# Altium schematic sheet VHF_144.SchDoc
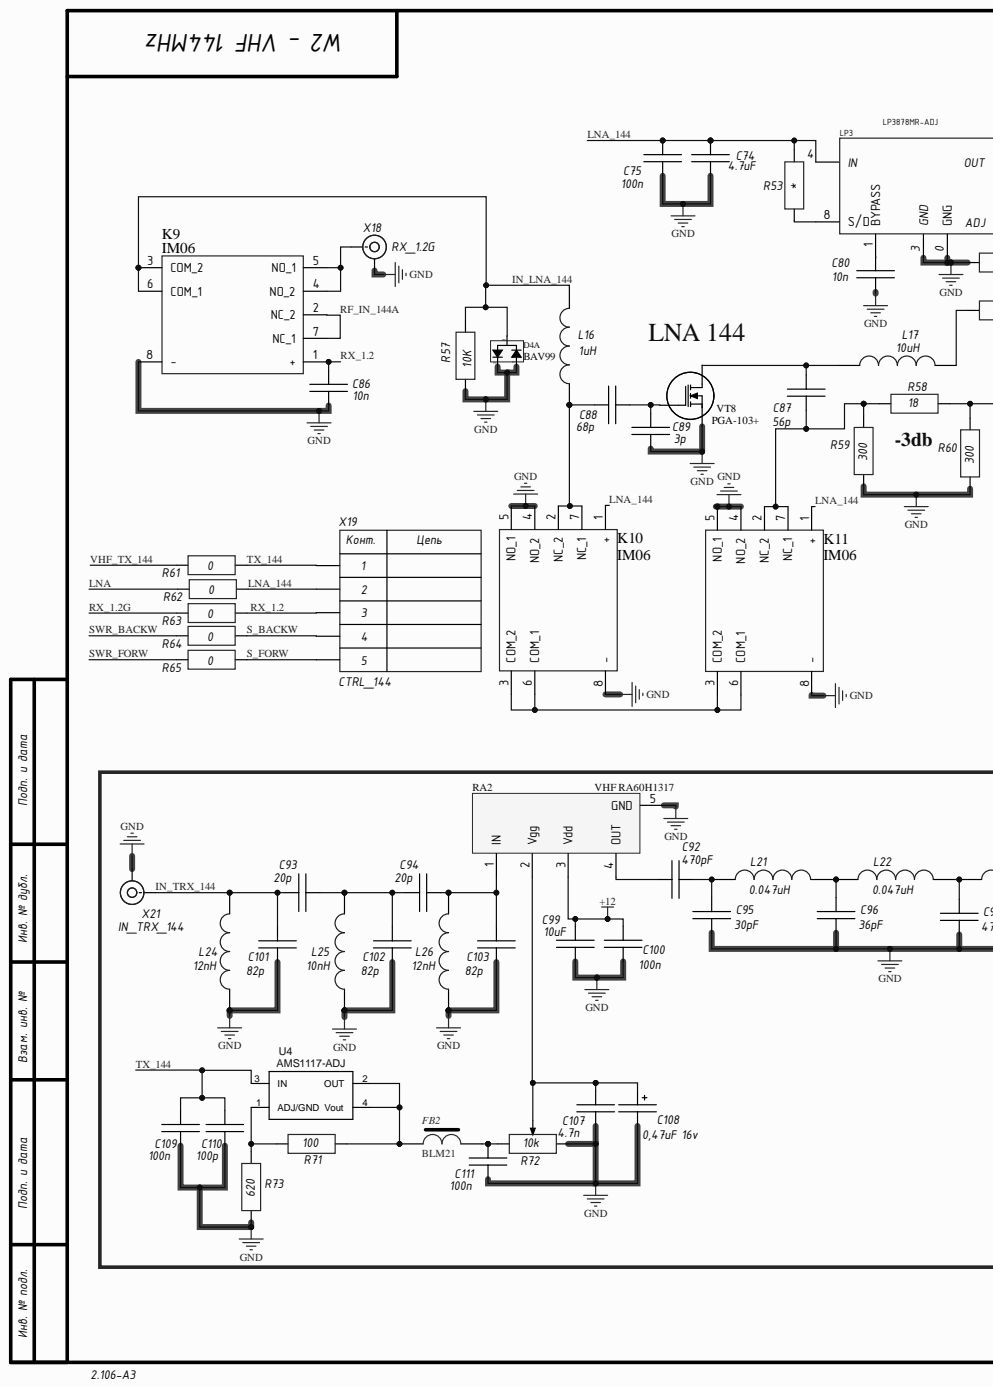
[diagram p0: VHF_144.SchDoc, left]
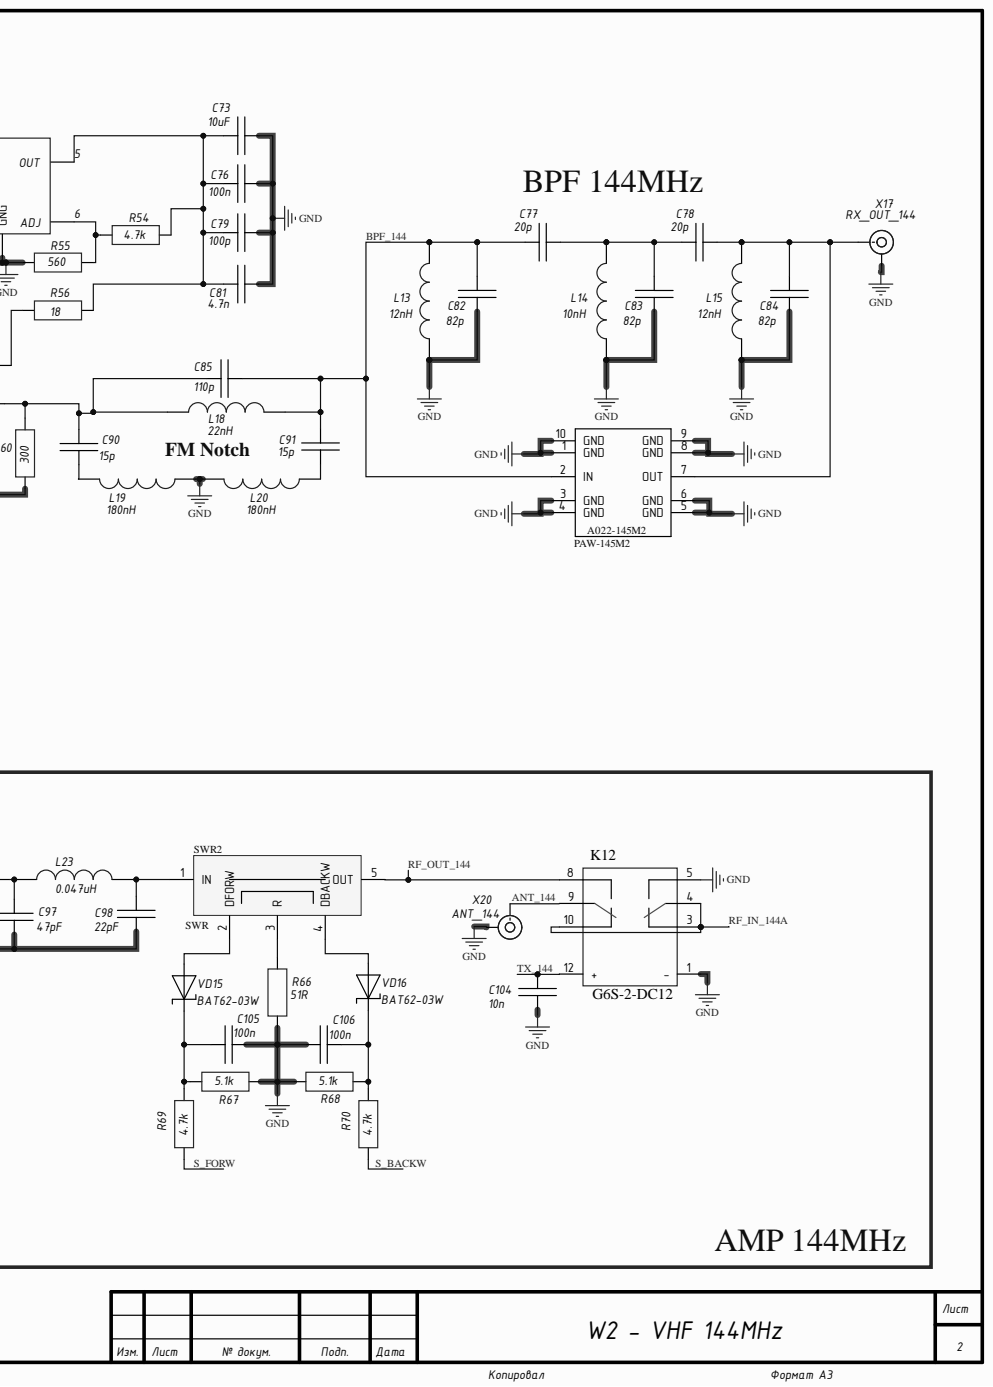
[diagram p1: VHF_144.SchDoc, right]

## Parts
C73  10uF  [CH0805C]
C74  4.7uF  [CH0805C]
C75  100n  [CH0805C]
C76  100n  [CH0805C]
C77  20p  [CH0805C]
C78  20p  [CH0805C]
C79  100p  [CH0805C]
C80  10n  [CH0805C]
C81  4.7n  [CH0805C]
C82  82p  [CH0805C]
C83  82p  [CH0805C]
C84  82p  [CH0805C]
C85  110p  [CH0805C]
C86  10n  [CH0805C]
C87  56p  [CH0805C]
C88  68p  [CH0805C]
C89  3p  [CH0805C]
C90  15p  [CH0805C]
C91  15p  [CH0805C]
C92  470pF  [CH1206ATC]
C93  20p  [CH0805C]
C94  20p  [CH0805C]
C95  30pF  [CH1206ATC]
C96  36pF  [CH1206ATC]
C97  47pF  [CH1206ATC]
C98  22pF  [CH1206ATC]
C99  10uF  [CH1206C]
C100  100n  [CH0805C]
C101  82p  [CH0805C]
C102  82p  [CH0805C]
C103  82p  [CH0805C]
C104  10n  [CH0805C]
C105  100n  [CH0805C]
C106  100n  [CH0805C]
C107  4.7n  [CH0805C]
C108  0,47uF 16v  [TANTAL_B]
C109  100n  [CH0805C]
C110  100p  [CH0805C]
C111  100n  [CH0805C]
D4  BAV99  [SOT23_132]  (part A)
FB2  BLM21  [CH0805L]
K9  IM06  [IM06]
K10  IM06  [IM06]
K11  IM06  [IM06]
K12  G6S-2-DC12  [G6S-2-DC12]
L13  12nH  [CH1206L]
L14  10nH  [CH1206L]
L15  12nH  [CH1206L]
L16  1uH  [CH1210L]
L17  10uH  [CH1210L]
L18  22nH  [CH1206L]
L19  180nH  [CH1206L]
L20  180nH  [CH1206L]
L21  0.047uH  [T50-6]
L22  0.047uH  [T50-6]
L23  0.047uH  [T50-6]
L24  12nH  [CH1206L]
L25  10nH  [CH1206L]
L26  12nH  [CH1206L]
LP3  LP3878MR-ADJ  [SOP-8 gnd]
PAW-1  PAW-145M2  [PAW-145M2]
R53  (no value)  [CH0805R]
R54  4.7k  [CH0805R]
R55  560  [CH0805R]
R56  18  [CH2010R]
R57  10K  [CH2010R]
R58  18  [CH0805R]
R59  300  [CH0805R]
R60  300  [CH0805R]
R61  0  [CH1206R]
R62  0  [CH1206R]
R63  0  [CH1206R]
R64  0  [CH1206R]
R65  0  [CH1206R]
R66  51R  [CH1206R]
R67  5.1k  [CH0805R]
R68  5.1k  [CH0805R]
R69  4.7k  [CH0805R]
R70  4.7k  [CH0805R]
R71  100  [CH0805R]
R72  10k  [RES: 3296W]
R73  620  [CH0805R]
RA2  VHF RA60H1317  [RA80H]
SWR2  SWR  [SWR_VHF]
U4  1117-ADJ  value AMS1117-ADJ  [LT1117CST-3.3]
VD15  BAT62-03W  [SOD323]
VD16  BAT62-03W  [SOD323]
VT8  PGA-103+  [SOT89_321_PGA103]
X17  RX_OUT_144  [CON: u.Fl]
X18  RX_1.2G  [CON: SMA]
X19  CTRL_144  [CON: PLS-5]
X20  ANT_144  [CON: SMA]
X21  IN_TRX_144  [CON: u.Fl]

## Nets
+12: C99.1 C100.2 RA2.3(Vdd)
GND: C73.2 C74.2 C75.2 C76.2 C79.2 C80.2 C81.2 C82.1 C83.1 C84.1 C86.1 C89.2 C95.1 C96.1 C97.1 C98.1 C99.2 C100.1 C101.1 C102.1 C103.1 C104.1 C105.1 C106.2 C107.1 C108.2 C109.2 C110.2 C111.1 D4.1 D4.2 K9.8(-) K10.4(NO_2) K10.5(NO_1) K10.8(-) K11.4(NO_2) K11.5(NO_1) K11.8(-) K12.1(-) K12.5 L13.2 L14.2 L15.2 L19.2 L20.1 L24.2 L25.2 L26.2 LP3.0(GNG) LP3.3(GND) PAW-1.1(GND) PAW-1.3(GND) PAW-1.4(GND) PAW-1.5(GND) PAW-1.6(GND) PAW-1.8(GND) PAW-1.9(GND) PAW-1.10(GND) R55.2 R57.1 R59.2 R60.2 R66.1 R67.1 R68.2 R72.3 R73.2 RA2.5(GND) VT8.2 X17.2 X18.2 X20.2 X21.2
ANT_144: K12.9 X20.1
BPF_144: C77.2 C82.2 C85.1 C91.2 L13.1 L18.1 PAW-1.2(IN)
IN_LNA_144: D4.3 K9.3(COM_2) K9.6(COM_1) L16.1 R57.2
IN_TRX_144: C93.2 C101.2 L24.1 X21.1
LNA: R62.1
LNA_144: C74.1 C75.1 K10.1(+) K11.1(+) LP3.4(IN) R53.1 R62.2 X19.2
RF_IN_144A: K9.2(NC_2) K9.7(NC_1) K12.3 K12.4 K12.10
RF_OUT_144: K12.8 SWR2.5(OUT)
RX_1.2: C86.2 K9.1(+) R63.2 X19.3
RX_1.2G: R63.1
S_BACKW: R64.2 R70.2 X19.4
S_FORW: R65.2 R69.2 X19.5
SWR_BACKW: R64.1
SWR_FORW: R65.1
TX_144: C104.2 C109.1 C110.1 K12.12(+) R61.2 U4.3(IN) X19.1
VHF_TX_144: R61.1
NetC73_1: C73.1 C76.1 C79.1 C81.1 LP3.5(OUT) R54.1 R56.1
NetC77_1: C77.1 C78.2 C83.2 L14.1
NetC78_1: C78.1 C84.2 L15.1 PAW-1.7(OUT) X17.1
NetC80_1: C80.1 LP3.1(BYPASS)
NetC85_2: C85.2 C90.2 L18.2 R58.1 R60.1
NetC87_1: C87.1 L17.2 VT8.1
NetC87_2: C87.2 K11.2(NC_2) K11.7(NC_1) R58.2 R59.1
NetC88_1: C88.1 C89.1 VT8.3
NetC88_2: C88.2 K10.2(NC_2) K10.7(NC_1) L16.2
NetC90_1: C90.1 L19.1
NetC91_1: C91.1 L20.2
NetC92_1: C92.1 RA2.4(OUT)
NetC92_2: C92.2 C95.2 L21.2
NetC93_1: C93.1 C94.2 C102.2 L25.1
NetC94_1: C94.1 C103.2 L26.1 RA2.1(IN)
NetC96_2: C96.2 L21.1 L22.2
NetC97_2: C97.2 L22.1 L23.2
NetC98_2: C98.2 L23.1 SWR2.1(IN)
NetC105_2: C105.2 R67.2 R69.1 VD15.2
NetC106_1: C106.1 R68.1 R70.1 VD16.2
NetC107_2: C107.2 C108.1 R72.2 RA2.2(Vgg)
NetC111_2: C111.2 FB2.1 R72.1
NetFB2_2: FB2.2 R71.1 U4.2(OUT) U4.4(Vout)
NetK9_4: K9.4(NO_2) K9.5(NO_1) X18.1
NetK10_3: K10.3(COM_2) K10.6(COM_1) K11.3(COM_2) K11.6(COM_1)
NetL17_1: L17.1 R56.2
NetLP3_6: LP3.6(ADJ) R54.2 R55.1
NetLP3_8: LP3.8(S/D) R53.2
NetR66_2: R66.2 SWR2.3(R)
NetR71_2: R71.2 R73.1 U4.1(ADJ/GND)
NetSWR2_2: SWR2.2(DFORW) VD15.1
NetSWR2_4: SWR2.4(DBACKW) VD16.1

## Library data of the parts
C73-C107 C109-C111 = Capacitor: Component Kind: Standard; Library Name: Discrete.SchLib
C108 = TantalCap: Component Kind: Standard; Library Name: Discrete.SchLib
FB2 = FB-1: Component Kind: Standard; Library Name: Discrete.SchLib
K9-K11 = IM06-V1: Component Kind: Standard; Library Name: Relay.SchLib
K12 = G6S-2-12VDC: Component Kind: Standard; Library Name: RU4PN.SchLib
L13-L20 L24-L26 = Inductor: Component Kind: Standard; Library Name: Discrete.SchLib
L21-L23 = Inductor ring: Value: {Value}; Component Kind: Standard; Library Name: Transformers.SchLib
LP3 = LP3878-ADJ: LP3878-ADJ HSOP-8; Component Kind: Standard; Library Name: RU4PN.SchLib
PAW-1 = PAW-145M2: PAW-A022-145M2; Component Kind: Standard; Library Name: RU4PN.SchLib
R53-R71 R73 = Resistor: Component Kind: Standard; Library Name: Discrete.SchLib
R72 = ADJ resistor: Component Kind: Standard; Library Name: Discrete.SchLib
RA2 = RA80H: Component Kind: Standard; Description: RA80H; Library Name: RU4PN.SchLib
SWR2 = SWR_VHF: Component Kind: Standard; Description: SWR_VHF; Library Name: RU4PN.SchLib
U4 = LT1117CST-3.3: LT1117CST-ADJ; Component Kind: Standard
VD15 VD16 = Diod Schottky: Component Kind: Standard; Library Name: Diods.SchLib
VT8 = N: Component Kind: Standard; Library Name: Transistor.SchLib
X17 X21 = u.Fl: RF; Manufacturer: Hirose Electric Co Ltd; Model: U.FL-R-SMT(01); Component Kind: Standard; Library Name: Connectors.SchLib
X18 X20 = SMA: RF; Component Kind: Standard; Library Name: Connectors.SchLib
X19 = 5-Connector: Component Kind: Standard; Library Name: Connectors.SchLib
D4 = BAV99: Component Kind: Standard; Library Name: Analog.SchLib
